# Altium schematic sheet receiver.SchDoc
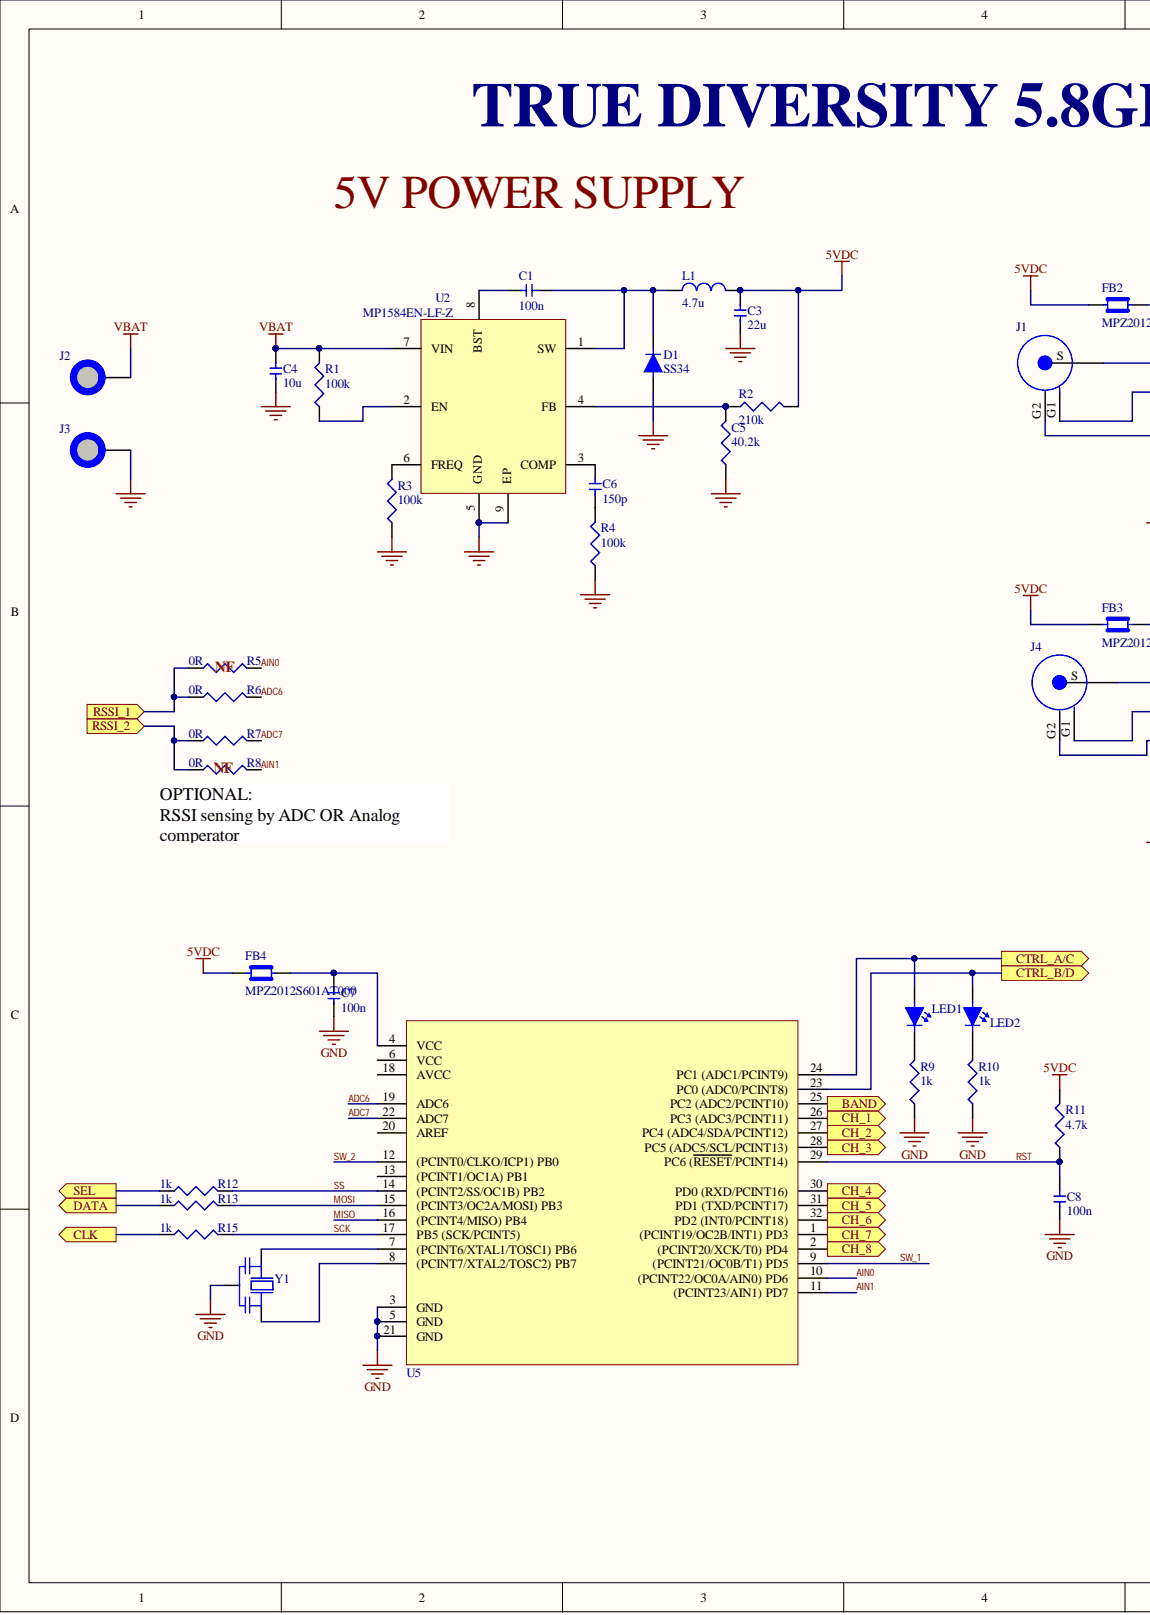
[diagram p0: receiver.SchDoc, left]
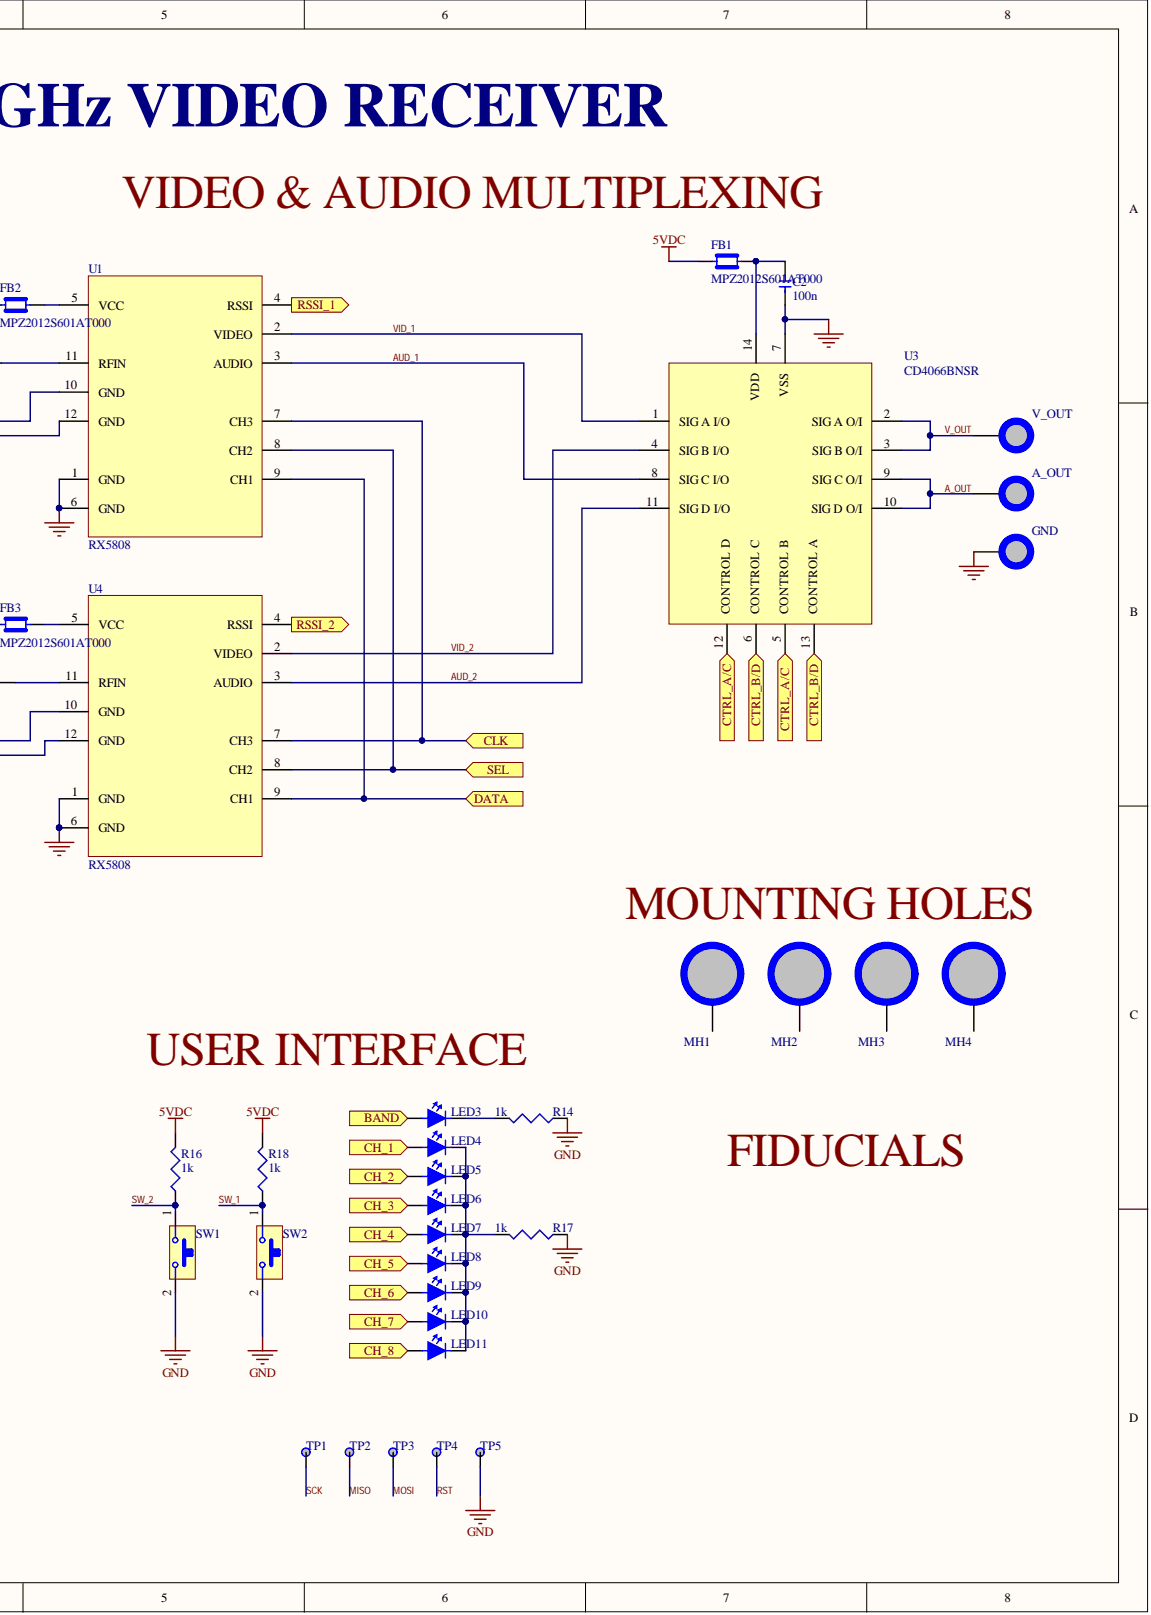
[diagram p1: receiver.SchDoc, right]

## Parts
A_OUT  PAD 2mmx3mm  [PAD 2x3]  (value not drawn)
C1  100n  [C0603]
C2  100n  [C0603]
C3  22u  [C1206]
C4  10u  [C1206]
C5  40.2k  [0603]
C6  150p  [C0603]
C7  100n  [C0603]
C8  100n  [C0603]
D1  SS34  [SS34]
FB1  MPZ2012S601AT000  [FB0805]
FB2  MPZ2012S601AT000  [FB0805]
FB3  MPZ2012S601AT000  [FB0805]
FB4  MPZ2012S601AT000  [FB0805]
GND  PAD 2mmx3mm  [PAD 2x3]  (value not drawn)
J1  EMPCB.SMAFSTJ.B.HT  [SMA EDGE]  (value not drawn)
J2  PAD 2mmx3mm  [PAD 2x3]  (value not drawn)
J3  PAD 2mmx3mm  [PAD 2x3]  (value not drawn)
J4  EMPCB.SMAFSTJ.B.HT  [SMA EDGE]  (value not drawn)
L1  4.7u  [inductor 6.5mm]
LED1  LWQ38E-Q1OO-3K6L-1  [LED0603]  (value not drawn)
LED2  LWQ38E-Q1OO-3K6L-1  [LED0603]  (value not drawn)
LED3  LWQ38E-Q1OO-3K6L-1  [LED0603]  (value not drawn)
LED4  LWQ38E-Q1OO-3K6L-1  [LED0603]  (value not drawn)
LED5  LWQ38E-Q1OO-3K6L-1  [LED0603]  (value not drawn)
LED6  LWQ38E-Q1OO-3K6L-1  [LED0603]  (value not drawn)
LED7  LWQ38E-Q1OO-3K6L-1  [LED0603]  (value not drawn)
LED8  LWQ38E-Q1OO-3K6L-1  [LED0603]  (value not drawn)
LED9  LWQ38E-Q1OO-3K6L-1  [LED0603]  (value not drawn)
LED10  LWQ38E-Q1OO-3K6L-1  [LED0603]  (value not drawn)
LED11  LWQ38E-Q1OO-3K6L-1  [LED0603]  (value not drawn)
MH1  MOUNTING HOLE 3x3  [MH3x3]  (value not drawn)
MH2  MOUNTING HOLE 3x3  [MH3x3]  (value not drawn)
MH3  MOUNTING HOLE 3x3  [MH3x3]  (value not drawn)
MH4  MOUNTING HOLE 3x3  [MH3x3]  (value not drawn)
R1  100k  [0603]
R2  210k  [0603]
R3  100k  [0603]
R4  100k  [0603]
R5  0R  [0603]
R6  0R  [0603]
R7  0R  [0603]
R8  0R  [0603]
R9  1k  [0603]
R10  1k  [0603]
R11  4.7k  [0603]
R12  1k  [0603]
R13  1k  [0603]
R14  1k  [0603]
R15  1k  [0603]
R16  1k  [0603]
R17  1k  [0603]
R18  1k  [0603]
SW1  RS-282G05A3-SMRT  [Tactile 6mmx36mm]  (value not drawn)
SW2  RS-282G05A3-SMRT  [Tactile 6mmx36mm]  (value not drawn)
TP1  TEST_POINT  [TEST_POINT]  (value not drawn)  (no BOM)
TP2  TEST_POINT  [TEST_POINT]  (value not drawn)  (no BOM)
TP3  TEST_POINT  [TEST_POINT]  (value not drawn)  (no BOM)
TP4  TEST_POINT  [TEST_POINT]  (value not drawn)  (no BOM)
TP5  TEST_POINT  [TEST_POINT]  (value not drawn)  (no BOM)
U1  RX5808  [RX5808]
U2  MP1584EN-LF-Z  [SOIC-8]
U3  CD4066BNSR  [SOP-14]
U4  RX5808  [RX5808]
U5  ATMEGA328PB-AUR  [TQFP32]  (value not drawn)
V_OUT  PAD 2mmx3mm  [PAD 2x3]  (value not drawn)
Y1  CSTCE16M0V53-R0  [CSTCE16M0V53-R0]  (value not drawn)

## Ports
BAND, CH_1, CH_2, CH_3, CH_4, CH_5, CH_6, CH_7, CH_8, CLK, CTRL_A/C, CTRL_B/D, DATA, RSSI_1, RSSI_2, SEL

## Nets
5VDC: C3.2 FB1.1 FB2.1 FB3.1 FB4.1 L1.2 R2.2 R11.2 R16.2 R18.2
GND: C2.1 C3.1 C4.1 C5.1 C7.1 C8.1 D1.A GND.1 J3.1 R3.1 R4.1 R9.1 R10.1 R14.1 R17.1 SW1.2 SW2.2 TP5.1 U1.1(GND) U1.6(GND) U2.5(GND) U2.9(EP) U3.7(VSS) U4.1(GND) U4.6(GND) U5.3(GND) U5.5(GND) U5.21(GND) Y1.2
VBAT: C4.2 J2.1 R1.2 U2.7(VIN)
A_OUT: A_OUT.1 U3.9(SIG_C_O/I) U3.10(SIG_D_O/I)
ADC6: R6.2 U5.19(ADC6)
ADC7: R7.2 U5.22(ADC7)
AIN0: R5.2 U5.10((PCINT22/OC0A/AIN0)_PD6)
AIN1: R8.2 U5.11((PCINT23/AIN1)_PD7)
AUD_1: U1.3(AUDIO) U3.8(SIG_C_I/O)
AUD_2: U3.11(SIG_D_I/O) U4.3(AUDIO)
BAND: LED3.A U5.25(PC2_(ADC2/PCINT10)) ; port BAND
CH_1: LED4.A U5.26(PC3_(ADC3/PCINT11)) ; port CH_1
CH_2: LED5.A U5.27(PC4_(ADC4/SDA/PCINT12)) ; port CH_2
CH_3: LED6.A U5.28(PC5_(ADC5/SCL/PCINT13)) ; port CH_3
CH_4: LED7.A U5.30(PD0_(RXD/PCINT16)) ; port CH_4
CH_5: LED8.A U5.31(PD1_(TXD/PCINT17)) ; port CH_5
CH_6: LED9.A U5.32(PD2_(INT0/PCINT18)) ; port CH_6
CH_7: LED10.A U5.1((PCINT19/OC2B/INT1)_PD3) ; port CH_7
CH_8: LED11.A U5.2((PCINT20/XCK/T0)_PD4) ; port CH_8
CLK: R15.1 U1.7(CH3) U4.7(CH3) ; port CLK
CTRL_A/C: LED1.A U3.5(CONTROL_B) U3.12(CONTROL_D) U5.24(PC1_(ADC1/PCINT9)) ; port CTRL_A/C
CTRL_B/D: LED2.A U3.6(CONTROL_C) U3.13(CONTROL_A) U5.23(PC0_(ADC0/PCINT8)) ; port CTRL_B/D
DATA: R13.1 U1.9(CH1) U4.9(CH1) ; port DATA
MISO: TP2.1 U5.16((PCINT4/MISO)_PB4)
MOSI: R13.2 TP3.1 U5.15((PCINT3/OC2A/MOSI)_PB3)
RSSI_1: R5.1 R6.1 U1.4(RSSI) ; port RSSI_1
RSSI_2: R7.1 R8.1 U4.4(RSSI) ; port RSSI_2
RST: C8.2 R11.1 TP4.1 U5.29(PC6_(RESET/PCINT14))
SCK: R15.2 TP1.1 U5.17(PB5_(SCK/PCINT5))
SEL: R12.1 U1.8(CH2) U4.8(CH2) ; port SEL
SS: R12.2 U5.14((PCINT2/SS/OC1B)_PB2)
SW_1: R18.1 SW2.1 U5.9((PCINT21/OC0B/T1)_PD5)
SW_2: R16.1 SW1.1 U5.12((PCINT0/CLKO/ICP1)_PB0)
V_OUT: U3.2(SIG_A_O/I) U3.3(SIG_B_O/I) V_OUT.1
VID_1: U1.2(VIDEO) U3.1(SIG_A_I/O)
VID_2: U3.4(SIG_B_I/O) U4.2(VIDEO)
NetC1_1: C1.1 U2.8(BST)
NetC1_2: C1.2 D1.K L1.1 U2.1(SW)
NetC2_2: C2.2 FB1.2 U3.14(VDD)
NetC5_2: C5.2 R2.1 U2.4(FB)
NetC6_1: C6.1 R4.2
NetC6_2: C6.2 U2.3(COMP)
NetC7_2: C7.2 FB4.2 U5.4(VCC)
NetFB2_2: FB2.2 U1.5(VCC)
NetFB3_2: FB3.2 U4.5(VCC)
NetJ1_G1: J1.G1 U1.10(GND)
NetJ1_G2: J1.G2 U1.12(GND)
NetJ1_S: J1.S U1.11(RFIN)
NetJ4_G1: J4.G1 U4.10(GND)
NetJ4_G2: J4.G2 U4.12(GND)
NetJ4_S: J4.S U4.11(RFIN)
NetLED1_K: LED1.K R9.2
NetLED2_K: LED2.K R10.2
NetLED3_K: LED3.K R14.2
NetLED4_K: LED4.K LED5.K LED6.K LED7.K LED8.K LED9.K LED10.K LED11.K R17.2
NetR1_1: R1.1 U2.2(EN)
NetR3_2: R3.2 U2.6(FREQ)
NetU5_7: U5.7((PCINT6/XTAL1/TOSC1)_PB6) Y1.1
NetU5_8: U5.8((PCINT7/XTAL2/TOSC2)_PB7) Y1.3

Not connected: MH1 1; MH2 1; MH3 1; MH4 1; U5 6(VCC) 13((PCINT1/OC1A)_PB1) 18(AVCC) 20(AREF)

## Library data of the parts
A_OUT GND J2 J3 V_OUT = PAD_2x3: Component Kind: Standard; Library Name: receiverSCHL.SchLib
C1 C2 C7 C8 = CC0603JRX7R9BB104: Cap Ceramic 0.1uF 50V X7R 5% SMD 0603 125C Paper T/R; Manufacturer: Yageo; Capacitance: 0.10µF; Applications: General Purpose; Category: Capacitors; Component Kind: Standard; ComponentLink1Description: http://www.yageo.com/documents/recent/UPY-GPHC_X7R_6.3V-to-50V_8.pdf; ComponentLink1URL: http://www.yageo.com/documents/recent/UPY-GPHC_X7R_6.3V-to-50V_8.pdf; Family: Ceramic Capacitors; Features: -; Height - Seated (Max): -; Lead Spacing: -; Lead Style: -; Manufacturer Part Number: CC0603JRX7R9BB104; Mounting Type: Surface Mount, MLCC; Operating Temperature: -55°C ~ 125°C; Package / Case: 0603 (1608 Metric); Packaging: Tape & Reel (TR); Pricing 1: 1=0.14, 10=0.097, 100=0.0454, 500=0.0318, 1000=0.0264 (USD); Ratings: -; Series: CC; Size / Dimension: 0.063" L x 0.031" W (1.60mm x 0.80mm); Stock 1: 40000; Supplier 1: Digi-Key; Supplier Part Number 1: 311-1779-1-ND; Temperature Coefficient: X7R; Thickness (Max): 0.035" (0.90mm); Tolerance: ±5%; Voltage - Rated: 50V; Library Name: receiverSCHL.SchLib
C3 = CC1206ZKY5V5BB226: Cap Ceramic 22uF 6.3V Y5V -20% to 80% SMD 1206 85C Blister T/R; Manufacturer: Yageo; Case Style: Ceramic Chip; Product Depth (mm): 1.6mm; Product Diameter (mm): Not Requiredmm; Product Length (mm): 3.2mm; Voltage: 6.3VDC; Wire Form: Not Required; Capacitance: 22uF; Component Kind: Standard; ComponentLink1Description: http://www.yageo.com/documents/recent/UPY-GPHC_Y5V_6.3V-to-50V_5.pdf; ComponentLink1URL: http://www.yageo.com/documents/recent/UPY-GPHC_Y5V_6.3V-to-50V_5.pdf; Construction: SMT Chip; Failure Rate: Not Required; Manufacturer Part Number: CC1206ZKY5V5BB226; Mounting Style: Surface Mount; Operating Temp Range: -30C to 85C; Package / Case: 1206; Packaging: Tape and Reel; Pricing 1: 1=0.52, 10=0.363, 100=0.218, 500=0.1635, 1000=0.1381 (USD); Product Height (mm): 1.6mm; Stock 1: 758; Supplier 1: Digi-Key; Supplier Part Number 1: 311-3461-1-ND; Temp Coeff (Dielectric): Y5V; Tolerance (+ or -): -20% to 80%; Library Name: receiverSCHL.SchLib
C4 = GRT31CR61H106ME01L: 10µF 50V Ceramic Capacitor X5R 1206 (3216 Metric) 0.126" L x 0.063" W (3.20mm x 1.60mm); Manufacturer: Murata; Tolerance: ±20%; Applications: Automotive; Capacitance: 10µF; Category: Capacitors; Component Kind: Standard; Family: Ceramic Capacitors; Features: -; Height - Seated (Max): -; Lead Spacing: -; Lead Style: -; Manufacturer Part Number: GRT31CR61H106ME01L; Mounting Type: Surface Mount, MLCC; Operating Temperature: -55°C ~ 85°C; Package / Case: 1206 (3216 Metric); Packaging: Tape & Reel (TR); Pricing 1: 1=0.57, 10=0.398, 100=0.2391, 500=0.1793, 1000=0.1514 (USD); Ratings: AEC-Q200; Series: GRT; Size / Dimension: 0.126" L x 0.063" W (3.20mm x 1.60mm); Stock 1: 20000; Supplier 1: Digi-Key; Supplier Part Number 1: 490-12457-1-ND; Temperature Coefficient: X5R; Thickness (Max): 0.071" (1.80mm); Voltage - Rated: 50V; Library Name: receiverSCHL.SchLib
C5 = RC0603FR-0740K2L: Yageo RC Series Thick Film Surface Mount Resistor 0603 Case 40200 1% 0.1W 100ppm/C; Case Height: 0.45 mm; Case Length: 1.6 mm; Case Width: 0.8 mm; Power Rating: 100 mW; Resistance: 40.2 kOhms; Type: General Purpose Chip Resistor Surface Mount; Voltage Rating: 50 V; Brand: Yageo; Case Code - in: 0603; Case Code - mm: 1608; Component Kind: Standard; ComponentLink1Description: http://www.mouser.com/ds/2/447/RC0603-1212058.pdf; ComponentLink1URL: http://www.mouser.com/ds/2/447/RC0603-1212058.pdf; ComponentLink2Description: http://documents.rs-components.com/techlib://itc_doclist_GB.htm?stockno=5047060; ComponentLink2URL: http://documents.rs-components.com/techlib://itc_doclist_GB.htm?stockno=5047060; Dimensions: 0.8 mm W x 1.6 mm L x 0.45 mm H; Manufacturer: Yageo; Manufacturer Part Number: RC0603FR-0740K2L; Operating Temperature Range: - 55 C to + 155 C; Package / Case: 0603 (1608 metric); Packaging: Reel; Pricing 1: 1=0.1, 10=0.024, 100=0.0097, 1000=0.0044, 2500=0.0038 (USD); Product: Thick Film Resistors General Purpose; Product Category: Thick Film Resistors - SMD; RoHS: Details; Series: RC; Stock 1: 1528046; Supplier 1: Digi-Key; Supplier Part Number 1: 311-40.2KHRCT-ND; Temperature Coefficient: 100 PPM / C; Termination Style: SMD/SMT; Tolerance: 1 %; Library Name: receiverSCHL.SchLib
C6 = CC0603JRNPO9BN151: CAP CER 150PF 50V NPO 0603; Manufacturer: Yageo; Featured Product: Commodity Multilayer Ceramic Capacitors – CC Series; Online Catalog: CC Series; Other Names: 311-1071-6 311-1289-6 311-1289-6-ND; Product Training Modules: Multilayer Ceramic Capacitors; Temperature Coefficient: C0G, NP0; Tolerance: ±5%; Applications: General Purpose; Capacitance: 150pF; Category: Capacitors; Component Kind: Standard; ComponentLink1Description: http://documents.rs-components.com/techlib://itc_doclist_GB.htm?stockno=6250251; ComponentLink1URL: http://documents.rs-components.com/techlib://itc_doclist_GB.htm?stockno=6250251; ComponentLink2Description: http://www.mouser.com/ds/2/447/Yageo_UPY-GP_NP0_16V-to-50V_9-358835.pdf; ComponentLink2URL: http://www.mouser.com/ds/2/447/Yageo_UPY-GP_NP0_16V-to-50V_9-358835.pdf; ComponentLink3Description: http://www.yageo.com/documents/recent/UPY-GP_NP0_16V-to-50V_8.pdf; ComponentLink3URL: http://www.yageo.com/documents/recent/UPY-GP_NP0_16V-to-50V_8.pdf; ComponentLink4Description: https://www.verical.com/server-webapp/html/getDatasheet?partID=317233; ComponentLink4URL: https://www.verical.com/server-webapp/html/getDatasheet?partID=317233; ComponentLink5Description: http://www.farnell.com/datasheets/1443161.pdf; ComponentLink5URL: http://www.farnell.com/datasheets/1443161.pdf; ComponentLink6Description: http://www.yageo.com/documents/recent/UPY-GP_NP0_16V-to-50V_16.pdf; ComponentLink6URL: http://www.yageo.com/documents/recent/UPY-GP_NP0_16V-to-50V_16.pdf; ComponentLink7Description: http://www.yageo.com/exep/pages/download/literatures/ProductSelectionGuide%202014_Final_2.pdf; ComponentLink7URL: http://www.yageo.com/exep/pages/download/literatures/ProductSelectionGuide%202014_Final_2.pdf; Family: Ceramic Capacitors; Features: -; Height - Seated (Max): -; Lead Spacing: -; Lead Style: -; Manufacturer Part Number: CC0603JRNPO9BN151; Mounting Type: Surface Mount, MLCC; Operating Temperature: -55°C ~ 125°C; Package / Case: 0603 (1608 Metric); Packaging: Digi-Reel®; Pricing 1: 1=0.1, 10=0.069, 100=0.0307, 500=0.0219, 1000=0.0172 (USD); Ratings: -; Series: CC; Size / Dimension: 0.063" L x 0.031" W (1.60mm x 0.80mm); Standard Package: 1; Stock 1: 40000; Supplier 1: Digi-Key; Supplier Part Number 1: 311-1071-1-ND; Thickness (Max): 0.035" (0.90mm); Voltage - Rated: 50V; Library Name: receiverSCHL.SchLib
D1 = SS34: DIODE SCHOTTKY 40V 3A SMC; Manufacturer: ON Semiconductor / Fairchild; Average Forward Current-Max (A): 3; CAGE Code: 1HBD3,07933,59621,7D893; Configuration: SINGLE; Diode Element Material: SILICON; JEDEC-95 Code: DO-214AB; Non-rep Pk Forward Current-Max (A): 100; Number of Elements: 1; Package Body Material: PLASTIC/EPOXY; Power Dissipation Limit-Max (W): 2.27; Rectifier Diode Application: GENERAL PURPOSE; Rectifier Diode Type: RECTIFIER DIODE; Rep Pk Reverse Voltage-Max (V): 40; Component Kind: Standard; ComponentLink1Description: https://www.fairchildsemi.com/datasheets/SS/SS39.pdf; ComponentLink1URL: https://www.fairchildsemi.com/datasheets/SS/SS39.pdf; ComponentLink2Description: http://www.mouser.com/ds/2/149/SS34-197057.pdf; ComponentLink2URL: http://www.mouser.com/ds/2/149/SS34-197057.pdf; ComponentLink3Description: http://www.jameco.com/Jameco/Products/ProdDS/1090087.pdf; ComponentLink3URL: http://www.jameco.com/Jameco/Products/ProdDS/1090087.pdf; ComponentLink4Description: https://www.fairchildsemi.com/package-drawings/DO/DO214AB.pdf; ComponentLink4URL: https://www.fairchildsemi.com/package-drawings/DO/DO214AB.pdf; ComponentLink5Description: http://www.farnell.com/datasheets/1774678.pdf; ComponentLink5URL: http://www.farnell.com/datasheets/1774678.pdf; ComponentLink6Description: http://www.jameco.com/Jameco/Products/ProdDS/1547649.pdf; ComponentLink6URL: http://www.jameco.com/Jameco/Products/ProdDS/1547649.pdf; JESD-30 Code: R-PDSO-C2; JESD-97 Code: e3; Lead Free: YES; Manufacturer Part Number: SS34; Moisture Sensitivity Level: 1; Number of Phases: 1; Number of Terminals: 2; Package Shape: RECTANGULAR; Package Style: SMALL OUTLINE; Peak Reflow Temperature (Cel): 260; Pricing 1: 1=0.66, 10=0.566, 100=0.4224, 500=0.3319, 1000=0.2565 (USD); RoHS Compliant: YES; Stock 1: 28296; Supplier 1: Digi-Key; Supplier Part Number 1: SS34FSDKR-ND; Surface Mount: YES; Technology: SCHOTTKY; Terminal Finish: MATTE TIN; Terminal Form: C BEND; Terminal Position: DUAL; Library Name: receiverSCHL.SchLib
FB1-FB4 = MPZ2012S601AT000: TDK   MPZ2012S601AT000   FERRITE BEAD, 0.1OHM, 2A, 0805, FULL REEL; PCN Part Number: MMZ,MPS Series Oct/2014; Catalog Drawings: (MMZ,MPZ)2012 Series Top    (MMZ,MPZ)2012 Series Footprint    (MMZ,MPZ)2012 Series Side; DC Resistance (DCR): 100 mOhm Max; Filter Type: Differential Mode - Single; Impedance @ Frequency: 600 Ohm @ 100MHz; Online Catalog: MPZ2012 Series; Other Names: 445-2206-6; Product Training Modules: EMC Components; Category: Filters; Component Kind: Standard; ComponentLink1Description: https://media.digikey.com/pdf/Data%20Sheets/TDK%20PDFs/MPZ_Series_Br.pdf; ComponentLink1URL: https://media.digikey.com/pdf/Data%20Sheets/TDK%20PDFs/MPZ_Series_Br.pdf; ComponentLink2Description: http://www.farnell.com/datasheets/1750041.pdf; ComponentLink2URL: http://www.farnell.com/datasheets/1750041.pdf; Current Rating: 2A; Family: Ferrite Beads and Chips; Height (Max): 0.041" (1.05mm); Manufacturer: TDK; Manufacturer Part Number: MPZ2012S601AT000; Mounting Type: Surface Mount; Package / Case: 0805 (2012 Metric); Packaging: Digi-Reel®; Pricing 1: 1=0.11, 10=0.091, 25=0.0704, 50=0.0584, 100=0.0503, 250=0.0403, 500=0.0362, 1000=0.0302 (USD); Series: MPZ; Size / Dimension: 0.079" L x 0.049" W (2.00mm x 1.25mm); Standard Package: 1; Stock 1: 352614; Supplier 1: Digi-Key; Supplier Part Number 1: 445-2206-1-ND; Library Name: receiverSCHL.SchLib
J1 J4 = EMPCB.SMAFSTJ.B.HT: CONN SMA JACK STR EDGE MNT; Manufacturer: Taoglas; Body Finish: Gold; Body Material: Brass; Connector Type: Jack, Female Socket; Contact Termination: Solder; Design Resources: Custom Cable Builder; Dielectric Material: Polytetrafluoroethylene (PTFE); Frequency - Max: 12.4GHz; Impedance: 50 Ohm; Other Names: 931-1175  EMPCBSMAFSTJBHT; Voltage Rating: 335V; Cable Group: -; Category: Connectors, Interconnects; Center Contact Material: Brass; Center Contact Plating: Gold; Component Kind: Standard; ComponentLink1Description: http://www.taoglas.com/wp-content/uploads/2016/01/EMPCB.SMAFSTJ.B.HT_.pdf; ComponentLink1URL: http://www.taoglas.com/wp-content/uploads/2016/01/EMPCB.SMAFSTJ.B.HT_.pdf; ComponentLink2Description: http://www.mouser.com/ds/2/398/EMPCB.SMAFSTJ.B.HT-19975.pdf; ComponentLink2URL: http://www.mouser.com/ds/2/398/EMPCB.SMAFSTJ.B.HT-19975.pdf; Connector Style: SMA; Family: Coaxial Connectors (RF); Fastening Type: Threaded; Features: -; Housing Color: Gold; Ingress Protection: -; Manufacturer Part Number: EMPCB.SMAFSTJ.B.HT; Mounting Type: Board Edge, End Launch; Online Catalog: SMA; Operating Temperature: -; Packaging: Tray; Pricing 1: 1=3.16, 100=3.0797, 500=2.8943, 1000=2.6986 (USD); Series: -; Shield Termination: Solder; Standard Package: 500; Stock 1: 6921; Supplier 1: Digi-Key; Supplier Part Number 1: 931-1175-ND; Library Name: receiverSCHL.SchLib
L1 = 0624CDMCCDS-4R7MC: 4.7µH Shielded Molded Inductor 4.8A 54 mOhm Max Nonstandard; Manufacturer: Sumida; Current - Saturation: 7.6A; Frequency - Test: 100kHz; Material - Core: Metal; Tolerance: ±20%; Category: Inductors, Coils, Chokes; Component Kind: Standard; Current Rating: 4.8A; DC Resistance (DCR): 54 mOhm Max; Family: Fixed Inductors; Frequency - Self Resonant: -; Height - Seated (Max): 0.094" (2.40mm); Inductance: 4.7µH; Manufacturer Part Number: 0624CDMCCDS-4R7MC; Mounting Type: Surface Mount; Operating Temperature: -55°C ~ 125°C; Package / Case: Nonstandard; Packaging: Tape & Reel (TR); Pricing 1: 1=0.92, 10=0.805, 100=0.575, 500=0.483 (USD); Q @ Freq: -; Ratings: -; Series: 0624CDMC/DS; Shielding: Shielded; Size / Dimension: 0.276" L x 0.260" W (7.00mm x 6.60mm); Stock 1: 2950; Supplier 1: Digikey; Supplier Part Number 1: 308-2452-1-ND; Type: Molded; Library Name: receiverSCHL.SchLib
LED1-LED11 = LWQ38E-Q1OO-3K6L-1: Yellow LED; Manufacturer: Osram Opto; Color: White; Intensity: 90(Max) mcd; Lens Appearance: Colored Diffused; Lens Shape Type: Rectangular; Luminous Flux: 0.24 lm; Mounting Orientation: Top Mount; Number of LEDs: 1; Type: Uni-Color; Viewing Angle: 150|130 °; Category: LEDs; Component Kind: Standard; ComponentLink1Description: http://datasheet.octopart.com/Q65110A7210-Osram-datasheet-13703461.pdf; ComponentLink1URL: http://datasheet.octopart.com/Q65110A7210-Osram-datasheet-13703461.pdf; Manufacturer Part Number: LWQ38E-Q1OO-3K6L-1; Mounting: Surface Mount; Pin Count: 2; Pricing 1: 1=0.49, 10=0.354, 25=0.2888, 100=0.231, 250=0.1949, 500=0.166, 1000=0.1299 (USD); Stock 1: 686093; Supplier 1: Digi-Key; Supplier Part Number 1: 475-2831-1-ND; Library Name: receiverSCHL.SchLib
R1 R3 R4 = RC0603FR-07100KL: YAGEO         RC0603FR-07100KL             RES, THICK FILM, 100K, 1%, 0.1W, 0603; Power Rating: 100 mW; Resistance: 100 kOhms; Temperature Coefficient: 100 PPM / C; Voltage Rating: 50 V; Case Code - in: 0603; Case Code - mm: 1608; Component Kind: Standard; ComponentLink1Description: http://www.farnell.com/datasheets/2167052.pdf; ComponentLink1URL: http://www.farnell.com/datasheets/2167052.pdf; ComponentLink2Description: http://www.farnell.com/datasheets/1868787.pdf; ComponentLink2URL: http://www.farnell.com/datasheets/1868787.pdf; ComponentLink3Description: http://www.farnell.com/datasheets/1738695.pdf; ComponentLink3URL: http://www.farnell.com/datasheets/1738695.pdf; ComponentLink4Description: http://documents.rs-components.com/techlib://itc_doclist_GB.htm?stockno=5048940; ComponentLink4URL: http://documents.rs-components.com/techlib://itc_doclist_GB.htm?stockno=5048940; ComponentLink5Description: http://www.farnell.com/datasheets/443971.pdf; ComponentLink5URL: http://www.farnell.com/datasheets/443971.pdf; Manufacturer: Yageo; Manufacturer Part Number: RC0603FR-07100KL; Operating Temperature Range: - 55 C to + 155 C; Packaging: Reel; Pricing 1: 1=0.1, 10=0.024, 100=0.0097, 1000=0.0044, 2500=0.0038 (USD); Product: Thick Film Resistors General Purpose; Series: RC; Stock 1: 56684824; Supplier 1: Digi-Key; Supplier Part Number 1: 311-100KHRCT-ND; Termination Style: SMD/SMT; Tolerance: 1 %; Library Name: receiverSCHL.SchLib
R2 = RC0603FR-07210KL: RES SMD 210K OHM 1% 1/10W 0603; Manufacturer: Yageo; Power Rating: 100 mW; Resistance: 210 kOhms; Temperature Coefficient: 100 PPM / C; Voltage Rating: 50 V; Case Code - in: 0603; Case Code - mm: 1608; Component Kind: Standard; ComponentLink1Description: http://www.yageo.com/documents/recent/PYu-RC_Group_51_RoHS_L_9.pdf; ComponentLink1URL: http://www.yageo.com/documents/recent/PYu-RC_Group_51_RoHS_L_9.pdf; ComponentLink2Description: http://documents.rs-components.com/techlib://itc_doclist_GB.htm?stockno=5050230; ComponentLink2URL: http://documents.rs-components.com/techlib://itc_doclist_GB.htm?stockno=5050230; Manufacturer Part Number: RC0603FR-07210KL; Operating Temperature Range: - 55 C to + 155 C; Packaging: Reel; Pricing 1: 1=0.1, 10=0.024, 100=0.0097, 1000=0.0044, 2500=0.0038 (USD); Product: Thick Film Resistors General Purpose; Series: RC; Stock 1: 301481; Supplier 1: Digi-Key; Supplier Part Number 1: 311-210KHRCT-ND; Termination Style: SMD/SMT; Tolerance: 1 %; Library Name: receiverSCHL.SchLib
R5-R8 = RC0603JR-070RL: YAGEO         RC0603JR-070RL             RES, THICK FILM, 0R, 0.1W, 0603; Power Rating: 100mW; Product Range: RC Series; Resistance: 0ohm; Resistance Tolerance: ± 5%; Resistor Case Style: 0603 [1608 Metric]; SVHC: To Be Advised; Temperature Coefficient: ± 200ppm/°C; Voltage Rating: 50V; Automotive Qualification Standard: -; Component Kind: Standard; ComponentLink1Description: http://www.farnell.com/datasheets/1868787.pdf; ComponentLink1URL: http://www.farnell.com/datasheets/1868787.pdf; ComponentLink2Description: https://www.verical.com/server-webapp/html/getDatasheet?partID=329462; ComponentLink2URL: https://www.verical.com/server-webapp/html/getDatasheet?partID=329462; ComponentLink3Description: http://www.yageo.com/documents/recent/PYu-RC_Group_51_RoHS_L_9.pdf; ComponentLink3URL: http://www.yageo.com/documents/recent/PYu-RC_Group_51_RoHS_L_9.pdf; ComponentLink4Description: http://www.farnell.com/datasheets/443971.pdf; ComponentLink4URL: http://www.farnell.com/datasheets/443971.pdf; ComponentLink5Description: http://documents.rs-components.com/techlib://itc_doclist_GB.htm?stockno=6515918; ComponentLink5URL: http://documents.rs-components.com/techlib://itc_doclist_GB.htm?stockno=6515918; Manufacturer: Yageo; Manufacturer Part Number: RC0603JR-070RL; MSL: MSL 1 - Unlimited; Packaging: Cut Tape; Pricing 1: 1=0.1, 10=0.021, 25=0.0152, 50=0.0114, 100=0.0084, 250=0.0064, 500=0.0051, 1000=0.0038 (USD); Resistor Element Type: Thick Film; Stock 1: 52668351; Supplier 1: Digi-Key; Supplier Part Number 1: 311-0.0GRCT-ND; Library Name: receiverSCHL.SchLib
R9 R10 R12-R18 = RC0603FR-071KL: YAGEO         RC0603FR-071KL.             RES, THICK FILM, 1K, 1%, 0.1W, 0603; Power Rating: 100 mW; Resistance: 1 kOhms; Temperature Coefficient: 100 PPM / C; Voltage Rating: 50 V; Case Code - in: 0603; Case Code - mm: 1608; Component Kind: Standard; ComponentLink1Description: http://documents.rs-components.com/techlib://itc_doclist_GB.htm?stockno=5048928; ComponentLink1URL: http://documents.rs-components.com/techlib://itc_doclist_GB.htm?stockno=5048928; ComponentLink2Description: http://www.yageo.com/documents/recent/PYu-RC0603_51_RoHS_L_4.pdf; ComponentLink2URL: http://www.yageo.com/documents/recent/PYu-RC0603_51_RoHS_L_4.pdf; ComponentLink3Description: http://www.farnell.com/datasheets/2167052.pdf; ComponentLink3URL: http://www.farnell.com/datasheets/2167052.pdf; ComponentLink4Description: http://www.farnell.com/datasheets/1868787.pdf; ComponentLink4URL: http://www.farnell.com/datasheets/1868787.pdf; ComponentLink5Description: http://www.yageo.com/documents/recent/PYu-RC_Group_51_RoHS_L_2.pdf; ComponentLink5URL: http://www.yageo.com/documents/recent/PYu-RC_Group_51_RoHS_L_2.pdf; ComponentLink6Description: https://www.verical.com/server-webapp/html/getDatasheet?partID=404327; ComponentLink6URL: https://www.verical.com/server-webapp/html/getDatasheet?partID=404327; ComponentLink7Description: http://www.farnell.com/datasheets/443971.pdf; ComponentLink7URL: http://www.farnell.com/datasheets/443971.pdf; Manufacturer: Yageo; Manufacturer Part Number: RC0603FR-071KL; Operating Temperature Range: - 55 C to + 155 C; Packaging: Reel; Pricing 1: 1=0.1, 10=0.024, 100=0.0097, 1000=0.0044, 2500=0.0038 (USD); Product: Thick Film Resistors General Purpose; Series: RC; Stock 1: 59894615; Supplier 1: Digi-Key; Supplier Part Number 1: 311-1.00KHRCT-ND; Termination Style: SMD/SMT; Tolerance: 1 %; Library Name: receiverSCHL.SchLib
R11 = RC0603FR-074K7L: YAGEO (PHYCOMP)         RC0603FR-074K7L.             SMD Chip Resistor, Thick Film, 4.7 kohm, 50 V, 0603 [1608 Metric], 100 mW,  1%, RC Series; Power Rating: 100 mW; Resistance: 4.7 kOhms; Temperature Coefficient: 100 PPM / C; Voltage Rating: 50 V; Case Code - in: 0603; Case Code - mm: 1608; Component Kind: Standard; ComponentLink1Description: https://www.verical.com/server-webapp/html/getDatasheet?partID=422754; ComponentLink1URL: https://www.verical.com/server-webapp/html/getDatasheet?partID=422754; ComponentLink2Description: http://documents.rs-components.com/techlib://itc_doclist_GB.htm?stockno=5047357; ComponentLink2URL: http://documents.rs-components.com/techlib://itc_doclist_GB.htm?stockno=5047357; ComponentLink3Description: http://www.farnell.com/datasheets/443971.pdf; ComponentLink3URL: http://www.farnell.com/datasheets/443971.pdf; ComponentLink4Description: http://www.farnell.com/datasheets/38590.pdf; ComponentLink4URL: http://www.farnell.com/datasheets/38590.pdf; ComponentLink5Description: http://www.farnell.com/datasheets/1868787.pdf; ComponentLink5URL: http://www.farnell.com/datasheets/1868787.pdf; Manufacturer: Yageo; Manufacturer Part Number: RC0603FR-074K7L; Operating Temperature Range: - 55 C to + 155 C; Packaging: Reel; Pricing 1: 1=0.1, 10=0.024, 100=0.0097, 1000=0.0044, 2500=0.0038 (USD); Product: Thick Film Resistors General Purpose; Series: RC; Stock 1: 22866503; Supplier 1: Digi-Key; Supplier Part Number 1: 311-4.70KHRCT-ND; Termination Style: SMD/SMT; Tolerance: 1 %; Library Name: receiverSCHL.SchLib
SW1 SW2 = RS-282G05A3-SMRT: SWITCH TACTILE SPST-NO 0.05A 12V; Manufacturer: ITT C&K; Actuator: Rectangular; Brand: C&K Components; Contact Form: SPST; Current Rating: 50 mA; Mounting Direction: Vertical; Operating Force: 2.5 N; Switch Function: OFF - (ON); Component Kind: Standard; ComponentLink1Description: https://media.digikey.com/pdf/Data%20Sheets/C&K/RS-282G05A-SM_RT.pdf; ComponentLink1URL: https://media.digikey.com/pdf/Data%20Sheets/C&K/RS-282G05A-SM_RT.pdf; ComponentLink2Description: http://www.mouser.com/catalog/specsheets/RS-282G05A-SM_RT.pdf; ComponentLink2URL: http://www.mouser.com/catalog/specsheets/RS-282G05A-SM_RT.pdf; Factory Pack Quantity: 3000; Manufacturer Part Number: RS-282G05A3-SMRT; Mounting Style: SMD/SMT; Packaging: Reel; Product Category: Tactile Switches; RoHS: Details; Series: RS2; Stock 1: 0; Supplier 1: Digi-Key; Supplier Part Number 1: CKN10384TR-ND; Voltage Rating DC: 12 V; Library Name: receiverSCHL.SchLib
U1 U4 = RX5808: Boscam RX5808; Component Kind: Standard; Library Name: receiverSCHL.SchLib
U2 = MP1584EN-LF-Z: Conv DC-DC Single Step Down 4.5V to 28V 8-Pin SOIC EP T/R; Manufacturer: Monolithic Power Systems; Brand: Monolithic Power Systems (MPS); Topology: Buck; Component Kind: Standard; Factory Pack Quantity: 2500; Manufacturer Part Number: MP1584EN-LF-Z; Packaging: Reel; Pricing 1: 1=3.03, 10=2.704, 25=2.4332, 100=2.2171, 250=2.0008, 500=1.7953, 1000=1.5141 (USD); Product Category: Voltage Regulators - Switching Regulators; RoHS: Details; Series: MP1584EN; Stock 1: 1546; Supplier 1: Digi-Key; Supplier Part Number 1: 1589-1533-1-ND; Library Name: receiverSCHL.SchLib
U3 = CD4066BNSR: IC QUAD BILATERAL SWITCH 14SO; Manufacturer: Texas Instruments; Analog Switch ON Resistance: 1050@5VOhm; Analog Switch Turn Off Time: 70@5Vns; Dual Supply Voltage (Max): ±9V; Dual Supply Voltage (Min): ±1.5V; Dual Supply Voltage (Typ): ±3/±5V; Multiplexer Configuration: Quad SPST; Number of Channels: 4; Power Supply Requirement: Single/Dual; Single Supply Voltage (Max): 18V; Single Supply Voltage (Min): 3V; Single Supply Voltage (Typ): 5/9/12/15V; Analog Switch Turn On Time: 70@5Vns; Component Kind: Standard; ComponentLink1Description: http://www.ti.com/lit/ds/symlink/cd4066b.pdf; ComponentLink1URL: http://www.ti.com/lit/ds/symlink/cd4066b.pdf; ComponentLink2Description: http://datasheet.iiic.cc/texas_instruments/CD4066BNSR.pdf; ComponentLink2URL: http://datasheet.iiic.cc/texas_instruments/CD4066BNSR.pdf; Manufacturer Part Number: CD4066BNSR; Mounting: Surface Mount; Number of Inputs: 4; Number of Outputs: 4; Operating Temperature Classification: Military; Operating Temp Range: -55C to 125C; Package Type: SOP; Packaging: Tape and Reel; Pin Count: 14; Pricing 1: 1=0.47, 10=0.402, 100=0.2999, 500=0.2357, 1000=0.1821 (USD); Stock 1: 3712; Supplier 1: Digi-Key; Supplier Part Number 1: 296-14122-1-ND; Library Name: receiverSCHL.SchLib
U5 = ATMEGA328PB-AUR: Manufacturer: Microchip; Component Kind: Standard; Manufacturer Part Number: ATMEGA328PB-AUR; Pricing 1: 1=1.46, 25=1.3392, 100=1.2154 (USD); Stock 1: 2825; Supplier 1: Digi-Key; Supplier Part Number 1: ATMEGA328PB-AURCT-ND; Library Name: receiverSCHL.SchLib
Y1 = CSTCE16M0V53-R0: CER RESONATOR 16.0MHZ SMD; Manufacturer: Murata; Capacitance: 15pF; Catalog Drawings: CSTCE-(G,V) Series Side 2    CSTCE-(G,V) Series Top    CSTCE-(G,V) Series Side 1    CSTCE-(G,V) Series Bottom; Dynamic Catalog: CERALOCK®, CSTCE Series; Frequency: 16MHz; Frequency Stability: ±0.3%; Frequency Tolerance: ±0.5%; Impedance: 40 Ohm; Other Names: 490-1198-1; Category: Crystals and Oscillators; Component Kind: Standard; ComponentLink1Description: https://www.verical.com/server-webapp/html/getDatasheet?partID=152969; ComponentLink1URL: https://www.verical.com/server-webapp/html/getDatasheet?partID=152969; ComponentLink2Description: https://www.murata.com/en-us/products/productdata/8796821913630/L0430E.pdf; ComponentLink2URL: https://www.murata.com/en-us/products/productdata/8796821913630/L0430E.pdf; ComponentLink3Description: http://www.farnell.com/datasheets/1506176.pdf; ComponentLink3URL: http://www.farnell.com/datasheets/1506176.pdf; ComponentLink4Description: https://www.distrelec.biz/Web/Downloads/_b/ro/ceralock_eng_bro.pdf?mime=application%2Fpdf; ComponentLink4URL: https://www.distrelec.biz/Web/Downloads/_b/ro/ceralock_eng_bro.pdf?mime=application%2Fpdf; ComponentLink5Description: http://search.murata.co.jp/Ceramy/image/img/w_hinm/L0430E.pdf; ComponentLink5URL: http://search.murata.co.jp/Ceramy/image/img/w_hinm/L0430E.pdf; Family: Resonators; Features: Built in Capacitor; Height: 0.039" (1.00mm); Manufacturer Part Number: CSTCE16M0V53-R0; Mounting Type: Surface Mount; Operating Temperature: -20°C ~ 80°C; Package / Case: 3-SMD, Non-Standard; Packaging: Cut Tape (CT); Series: CERALOCK®; Size / Dimension: 0.126" L x 0.051" W (3.20mm x 1.30mm); Standard Package: 1; Stock 1: 0; Supplier 1: Digi-Key; Supplier Part Number 1: 490-1198-1-ND; Type: Ceramic; Library Name: receiverSCHL.SchLib
MH1-MH4 = MOUNTING HOLE 3x3: Component Kind: Standard; Library Name: receiverSCHL.SchLib
TP1-TP5 = TEST_POINT: Library Name: receiverSCHL.SchLib
